# Altium schematic sheet IND_ENC_v2r0.SchDoc
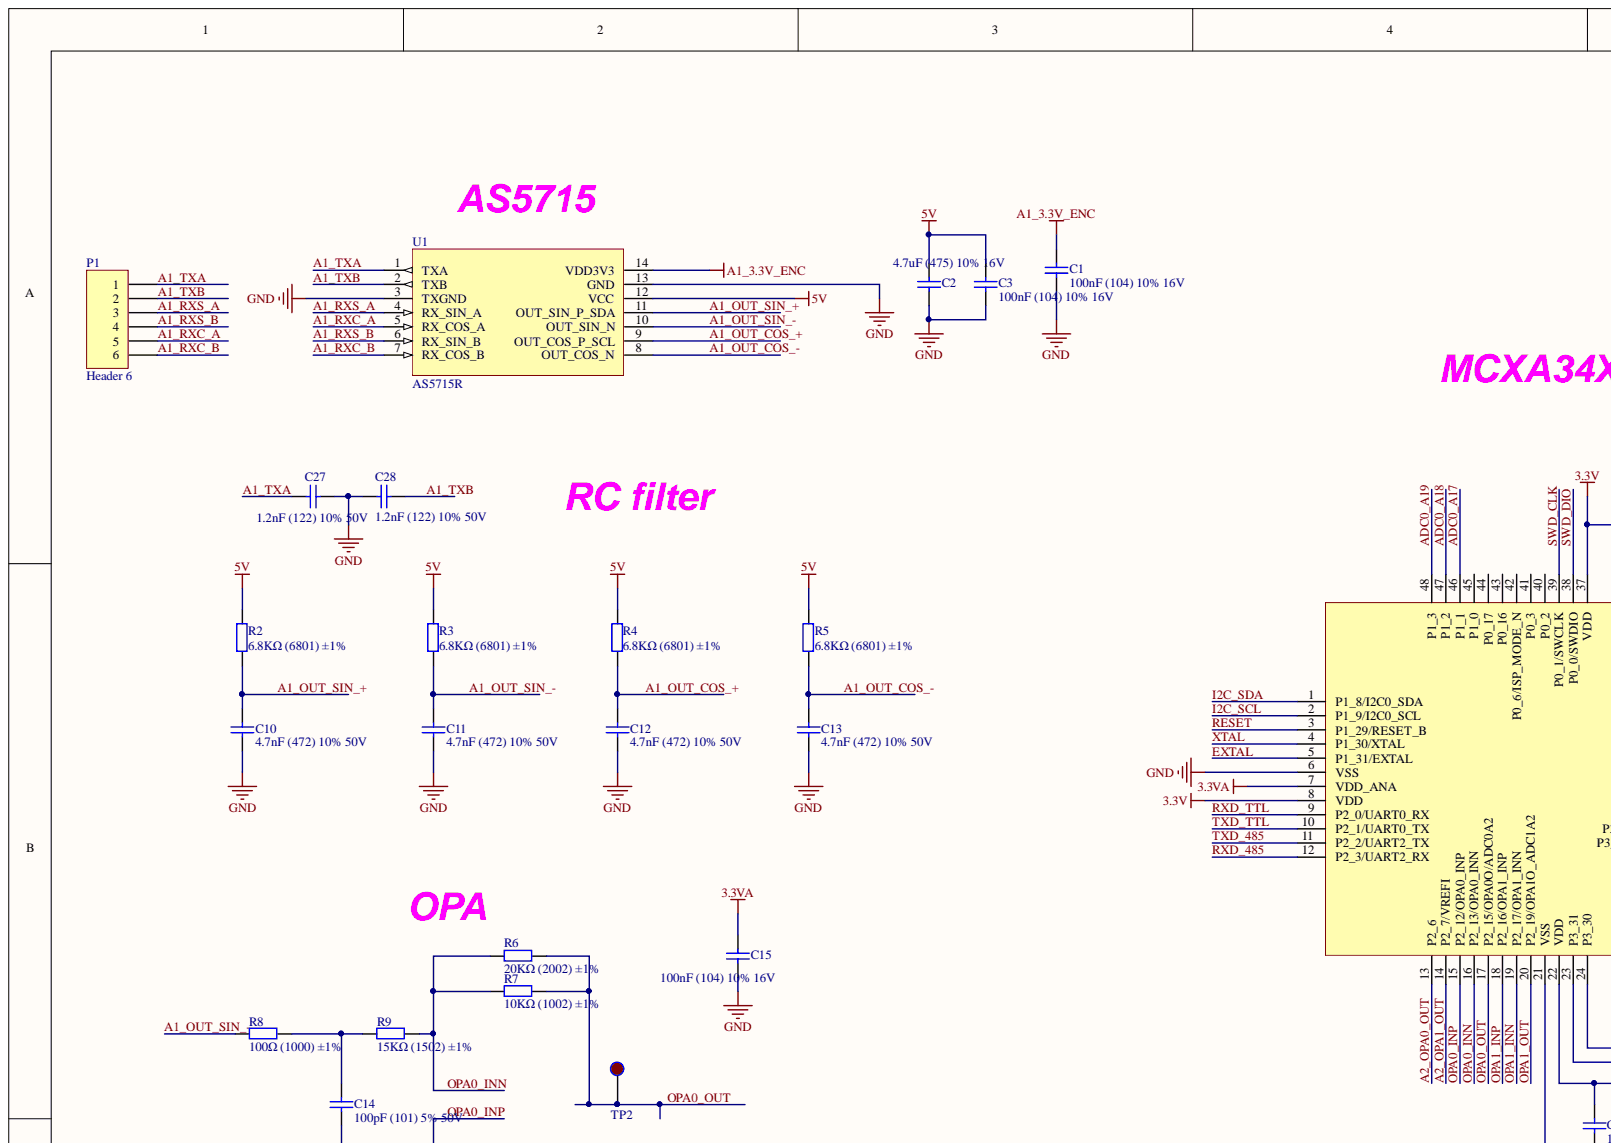
[diagram p0: IND_ENC_v2r0.SchDoc, top left]
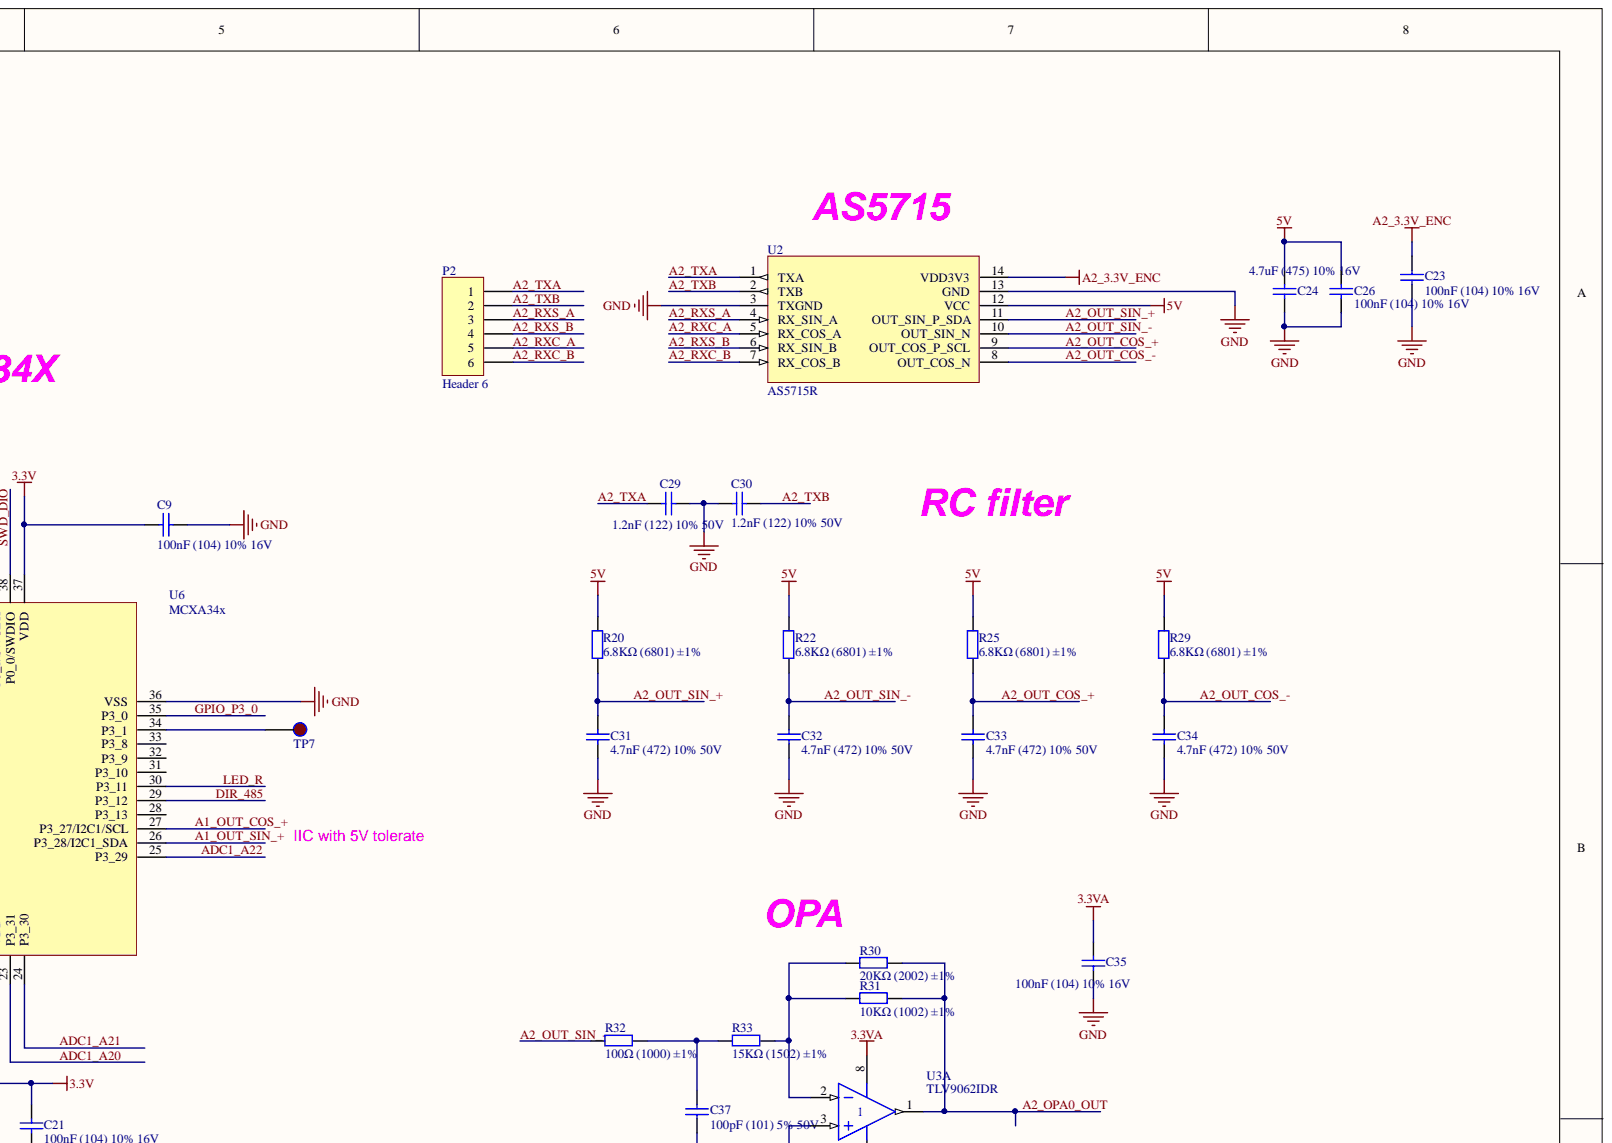
[diagram p1: IND_ENC_v2r0.SchDoc, top right]
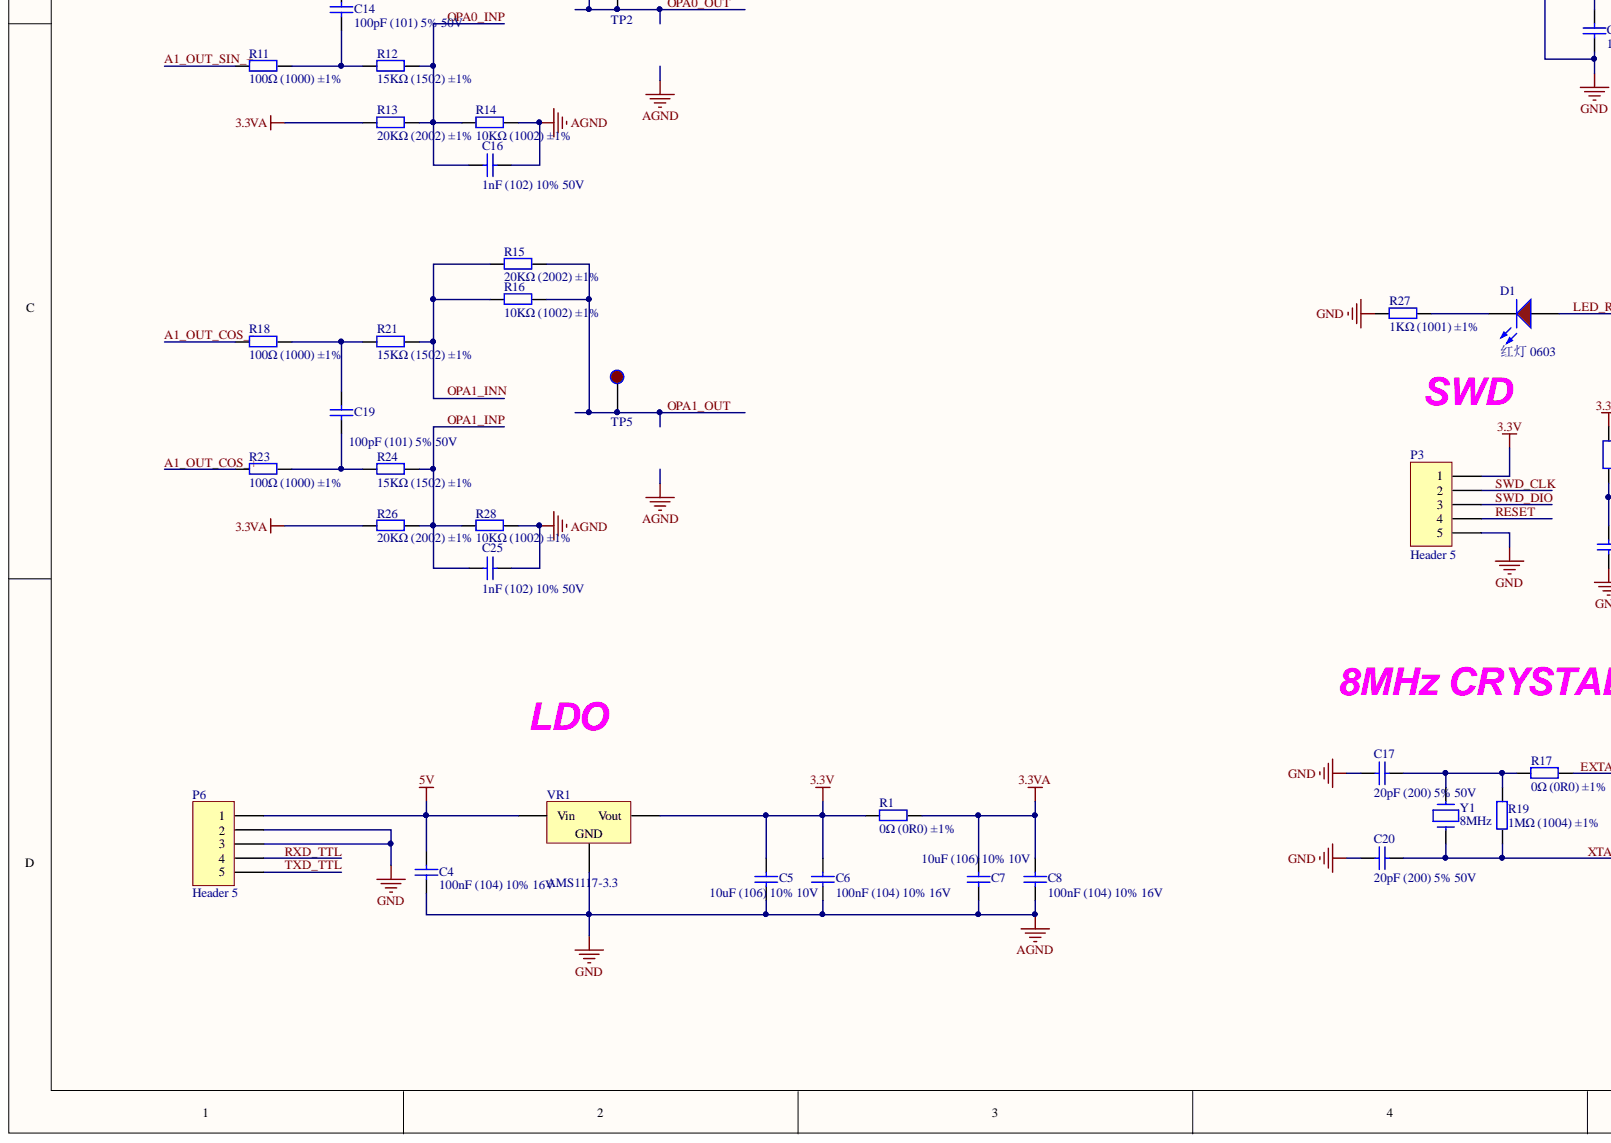
[diagram p2: IND_ENC_v2r0.SchDoc, bottom left]
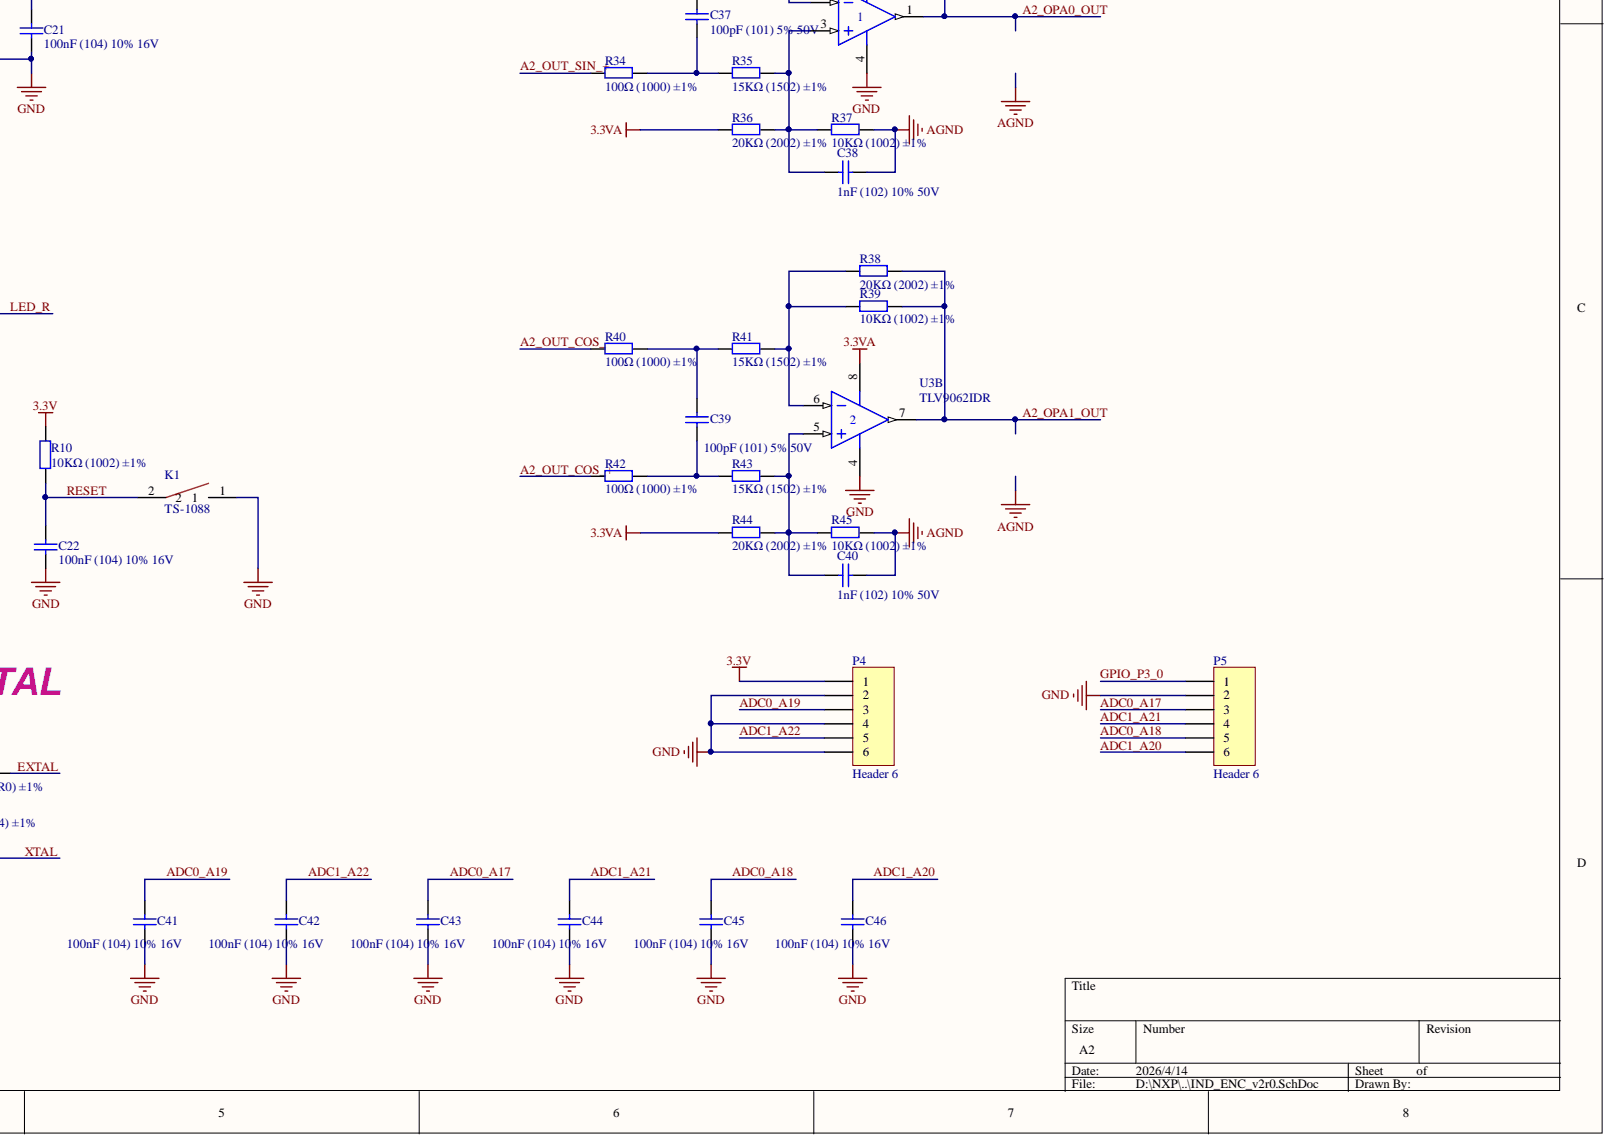
[diagram p3: IND_ENC_v2r0.SchDoc, bottom right]

## Parts
C1  100nF (104) 10% 16V  [0402_C]
C2  4.7uF (475) 10% 16V  [0603_C]
C3  100nF (104) 10% 16V  [0402_C]
C4  100nF (104) 10% 16V  [0402_C]
C5  10uF (106) 10% 10V  [0603_C]
C6  100nF (104) 10% 16V  [0402_C]
C7  10uF (106) 10% 10V  [0603_C]
C8  100nF (104) 10% 16V  [0402_C]
C9  100nF (104) 10% 16V  [0402_C]
C10  4.7nF (472) 10% 50V  [0402_C]
C11  4.7nF (472) 10% 50V  [0402_C]
C12  4.7nF (472) 10% 50V  [0402_C]
C13  4.7nF (472) 10% 50V  [0402_C]
C14  100pF (101) 5% 50V  [0402_C]
C15  100nF (104) 10% 16V  [0402_C]
C16  1nF (102) 10% 50V  [0402_C]
C17  20pF (200) 5% 50V  [0603_C]
C19  100pF (101) 5% 50V  [0402_C]
C20  20pF (200) 5% 50V  [0603_C]
C21  100nF (104) 10% 16V  [0402_C]
C22  100nF (104) 10% 16V  [0402_C]
C23  100nF (104) 10% 16V  [0402_C]
C24  4.7uF (475) 10% 16V  [0603_C]
C25  1nF (102) 10% 50V  [0402_C]
C26  100nF (104) 10% 16V  [0402_C]
C27  1.2nF (122) 10% 50V  [0603_C]
C28  1.2nF (122) 10% 50V  [0603_C]
C29  1.2nF (122) 10% 50V  [0603_C]
C30  1.2nF (122) 10% 50V  [0603_C]
C31  4.7nF (472) 10% 50V  [0402_C]
C32  4.7nF (472) 10% 50V  [0402_C]
C33  4.7nF (472) 10% 50V  [0402_C]
C34  4.7nF (472) 10% 50V  [0402_C]
C35  100nF (104) 10% 16V  [0402_C]
C37  100pF (101) 5% 50V  [0402_C]
C38  1nF (102) 10% 50V  [0402_C]
C39  100pF (101) 5% 50V  [0402_C]
C40  1nF (102) 10% 50V  [0402_C]
C41  100nF (104) 10% 16V  [0402_C]
C42  100nF (104) 10% 16V  [0402_C]
C43  100nF (104) 10% 16V  [0402_C]
C44  100nF (104) 10% 16V  [0402_C]
C45  100nF (104) 10% 16V  [0402_C]
C46  100nF (104) 10% 16V  [0402_C]
D1  红灯 0603  Package: 0603  [0603_LED_S1]
K1  TS-1088  [SW-SMD_L3.9-W3.0-P4.45]
P1  Header 6  [HDR1X6]
P2  Header 6  [HDR1X6]
P3  Header 5  [HDR1X5]
P4  Header 6  [HDR1X6]
P5  Header 6  [HDR1X6]
P6  Header 5  [HDR1X5]
R1  0Ω (0R0) ±1%  [0603_R]
R2  6.8KΩ (6801) ±1%  [0402_R]
R3  6.8KΩ (6801) ±1%  [0402_R]
R4  6.8KΩ (6801) ±1%  [0402_R]
R5  6.8KΩ (6801) ±1%  [0402_R]
R6  20KΩ (2002) ±1%  [0402_R]
R7  10KΩ (1002) ±1%  [0402_R]
R8  100Ω (1000) ±1%  [0402_R]
R9  15KΩ (1502) ±1%  [0402_R]
R10  10KΩ (1002) ±1%  [0402_R]
R11  100Ω (1000) ±1%  [0402_R]
R12  15KΩ (1502) ±1%  [0402_R]
R13  20KΩ (2002) ±1%  [0402_R]
R14  10KΩ (1002) ±1%  [0402_R]
R15  20KΩ (2002) ±1%  [0402_R]
R16  10KΩ (1002) ±1%  [0402_R]
R17  0Ω (0R0) ±1%  [0603_R]
R18  100Ω (1000) ±1%  [0402_R]
R19  1MΩ (1004) ±1%  [0603_R]
R20  6.8KΩ (6801) ±1%  [0402_R]
R21  15KΩ (1502) ±1%  [0402_R]
R22  6.8KΩ (6801) ±1%  [0402_R]
R23  100Ω (1000) ±1%  [0402_R]
R24  15KΩ (1502) ±1%  [0402_R]
R25  6.8KΩ (6801) ±1%  [0402_R]
R26  20KΩ (2002) ±1%  [0402_R]
R27  1KΩ (1001) ±1%  [0603_R]
R28  10KΩ (1002) ±1%  [0402_R]
R29  6.8KΩ (6801) ±1%  [0402_R]
R30  20KΩ (2002) ±1%  [0402_R]
R31  10KΩ (1002) ±1%  [0402_R]
R32  100Ω (1000) ±1%  [0402_R]
R33  15KΩ (1502) ±1%  [0402_R]
R34  100Ω (1000) ±1%  [0402_R]
R35  15KΩ (1502) ±1%  [0402_R]
R36  20KΩ (2002) ±1%  [0402_R]
R37  10KΩ (1002) ±1%  [0402_R]
R38  20KΩ (2002) ±1%  [0402_R]
R39  10KΩ (1002) ±1%  [0402_R]
R40  100Ω (1000) ±1%  [0402_R]
R41  15KΩ (1502) ±1%  [0402_R]
R42  100Ω (1000) ±1%  [0402_R]
R43  15KΩ (1502) ±1%  [0402_R]
R44  20KΩ (2002) ±1%  [0402_R]
R45  10KΩ (1002) ±1%  [0402_R]
TP2  TP?  [TPP]
TP5  TP?  [TPP]
TP7  TP?  [TP]
U1  AS5715R  [TSSOP-14]
U2  AS5715R  [TSSOP-14]
U3  TLV9062IDR  [SOIC-8_150mil]  (parts A,B)
U6  MCXA34x  [LQFP48]
VR1  AMS1117-3.3  [SOT-223]
Y1  8MHz  [SMD_5032_2P]

## Nets
3.3V: C5.2 C6.2 C9.2 C21.2 P3.1 P4.1 R1.1 R10.2 U6.8(VDD) U6.22(VDD) U6.37(VDD) VR1.2
3.3VA: C7.2 C8.2 C15.2 C35.2 R1.2 R13.1 R26.1 R36.1 R44.1 U3.8 U6.7(VDD_ANA)
5V: C2.2 C3.2 C4.2 C24.2 C26.2 P6.1 R2.2 R3.2 R4.2 R5.2 R20.2 R22.2 R25.2 R29.2 U1.12(VCC) U2.12(VCC) VR1.3
A1_3.3V_ENC: C1.2 U1.14(VDD3V3)
A2_3.3V_ENC: C23.2 U2.14(VDD3V3)
AGND: C1.1 C2.1 C3.1 C4.1 C5.1 C6.1 C7.1 C8.1 C9.1 C10.1 C11.1 C12.1 C13.1 C15.1 C16.2 C17.2 C20.2 C21.1 C22.1 C23.1 C24.1 C25.2 C26.1 C27.2 C28.1 C29.2 C30.1 C31.1 C32.1 C33.1 C34.1 C35.1 C38.2 C40.2 C41.1 C42.1 C43.1 C44.1 C45.1 C46.1 K1.1 P3.5 P4.2 P4.4 P4.6 P5.2 P6.2 P6.3 R14.2 R27.1 R28.2 R37.2 R45.2 U1.3(TXGND) U1.13(GND) U2.3(TXGND) U2.13(GND) U3.4 U6.6(VSS) U6.21(VSS) U6.36(VSS) VR1.1
A1_OUT_COS_+: C12.2 R4.1 R23.1 U1.9(OUT_COS_P_SCL) U6.27(P3_27/I2C1/SCL)
A1_OUT_COS_-: C13.2 R5.1 R18.1 U1.8(OUT_COS_N)
A1_OUT_SIN_+: C10.2 R2.1 R11.1 U1.11(OUT_SIN_P_SDA) U6.26(P3_28/I2C1_SDA)
A1_OUT_SIN_-: C11.2 R3.1 R8.1 U1.10(OUT_SIN_N)
A1_RXC_A: P1.5 U1.5(RX_COS_A)
A1_RXC_B: P1.6 U1.7(RX_COS_B)
A1_RXS_A: P1.3 U1.4(RX_SIN_A)
A1_RXS_B: P1.4 U1.6(RX_SIN_B)
A1_TXA: C27.1 P1.1 U1.1(TXA)
A1_TXB: C28.2 P1.2 U1.2(TXB)
A2_OPA0_OUT: R30.2 R31.2 U3.1 U6.13(P2_6)
A2_OPA1_OUT: R38.2 R39.2 U3.7 U6.14(P2_7/VREFI)
A2_OUT_COS_+: C33.2 R25.1 R42.1 U2.9(OUT_COS_P_SCL)
A2_OUT_COS_-: C34.2 R29.1 R40.1 U2.8(OUT_COS_N)
A2_OUT_SIN_+: C31.2 R20.1 R34.1 U2.11(OUT_SIN_P_SDA)
A2_OUT_SIN_-: C32.2 R22.1 R32.1 U2.10(OUT_SIN_N)
A2_RXC_A: P2.5 U2.5(RX_COS_A)
A2_RXC_B: P2.6 U2.7(RX_COS_B)
A2_RXS_A: P2.3 U2.4(RX_SIN_A)
A2_RXS_B: P2.4 U2.6(RX_SIN_B)
A2_TXA: C29.1 P2.1 U2.1(TXA)
A2_TXB: C30.2 P2.2 U2.2(TXB)
ADC0_A17: C43.2 P5.3 U6.46(P1_1)
ADC0_A18: C45.2 P5.5 U6.47(P1_2)
ADC0_A19: C41.2 P4.3 U6.48(P1_3)
ADC1_A20: C46.2 P5.6 U6.23(P3_31)
ADC1_A21: C44.2 P5.4 U6.24(P3_30)
ADC1_A22: C42.2 P4.5 U6.25(P3_29)
DIR_485: U6.29(P3_12)
EXTAL: R17.1 U6.5(P1_31/EXTAL)
GPIO_P3_0: P5.1 U6.35(P3_0)
I2C_SCL: U6.2(P1_9/I2C0_SCL)
I2C_SDA: U6.1(P1_8/I2C0_SDA)
LED_R: D1.A U6.30(P3_11)
OPA0_INN: R6.1 R7.1 R9.2 U6.16(P2_13/OPA0_INN)
OPA0_INP: C16.1 R12.2 R13.2 R14.1 U6.15(P2_12/OPA0_INP)
OPA0_OUT: R6.2 R7.2 TP2.1 U6.17(P2_15/OPA0O/ADC0A2)
OPA1_INN: R15.1 R16.1 R21.2 U6.19(P2_17/OPA1_INN)
OPA1_INP: C25.1 R24.2 R26.2 R28.1 U6.18(P2_16/OPA1_INP)
OPA1_OUT: R15.2 R16.2 TP5.1 U6.20(P2_19/OPA1O_ADC1A2)
RESET: C22.2 K1.2 P3.4 R10.1 U6.3(P1_29/RESET_B)
RXD_485: U6.12(P2_3/UART2_RX)
RXD_TTL: P6.4 U6.9(P2_0/UART0_RX)
SWD_CLK: P3.2 U6.39(P0_1/SWCLK)
SWD_DIO: P3.3 U6.38(P0_0/SWDIO)
TXD_485: U6.11(P2_2/UART2_TX)
TXD_TTL: P6.5 U6.10(P2_1/UART0_TX)
XTAL: C20.1 R19.1 U6.4(P1_30/XTAL) Y1.1
NetC14_1: C14.1 R11.2 R12.1
NetC14_2: C14.2 R8.2 R9.1
NetC17_1: C17.1 R17.2 R19.2 Y1.2
NetC19_1: C19.1 R23.2 R24.1
NetC19_2: C19.2 R18.2 R21.1
NetC37_1: C37.1 R34.2 R35.1
NetC37_2: C37.2 R32.2 R33.1
NetC38_1: C38.1 R35.2 R36.2 R37.1 U3.3
NetC39_1: C39.1 R42.2 R43.1
NetC39_2: C39.2 R40.2 R41.1
NetC40_1: C40.1 R43.2 R44.2 R45.1 U3.5
NetD1_K: D1.K R27.2
NetR30_1: R30.1 R31.1 R33.2 U3.2
NetR38_1: R38.1 R39.1 R41.2 U3.6
NetTP7_1: TP7.1 U6.34(P3_1)

Not connected: U6 28(P3_13) 31(P3_10) 32(P3_9) 33(P3_8) 40(P0_2) 41(P0_3) 42(P0_6/ISP_MODE_N) 43(P0_16) 44(P0_17) 45(P1_0)

## Library data of the parts
C1 C3 C4 C6 C8 C9 C15 C21-C23 C26 C35 C41-C46 = 0402 100nF (104) 10% 16V: 贴片电容; Component Kind: Standard; ComponentLink1Description: 供应商链接; ComponentLink1URL: http://www.szlcsc.com/product/details_1877.html; Library Name: Cap.SchLib; Package: 0402; Supplier: LC; SuppliersPartNumber: C1525
C2 C24 = 0603 4.7uF (475) 10% 16V: 贴片电容; Component Kind: Standard; ComponentLink1Description: 供应商链接; ComponentLink1URL: http://www.szlcsc.com/product/details_20375.html; Library Name: Cap.SchLib; Package: 0603; Supplier: LC; SuppliersPartNumber: C19666
C5 C7 = 0603 10uF (106) 10% 10V: 贴片电容; Component Kind: Standard; ComponentLink1Description: 供应商链接; ComponentLink1URL: http://www.szlcsc.com/product/details_20411.html; Library Name: Cap.SchLib; Package: 0603; Supplier: LC; SuppliersPartNumber: C19702
C10-C13 C31-C34 = 0402 4.7nF (472) 10% 50V: 贴片电容; Component Kind: Standard; ComponentLink1Description: 供应商链接; ComponentLink1URL: http://www.szlcsc.com/product/details_1890.html; Library Name: Cap.SchLib; Package: 0402; Supplier: LC; SuppliersPartNumber: C1538
C14 C19 C37 C39 = 0402 100pF (101) 5% 50V: 贴片电容; Component Kind: Standard; ComponentLink1Description: 供应商链接; ComponentLink1URL: http://www.szlcsc.com/product/details_1898.html; Library Name: Cap.SchLib; Package: 0402; Supplier: LC; SuppliersPartNumber: C1546
C16 C25 C38 C40 = 0402 1nF (102) 10% 50V: 贴片电容; Component Kind: Standard; ComponentLink1Description: 供应商链接; ComponentLink1URL: http://www.szlcsc.com/product/details_1875.html; Library Name: Cap.SchLib; Package: 0402; Supplier: LC; SuppliersPartNumber: C1523
C17 C20 = 0603 20pF (200) 5% 50V: 贴片电容; Component Kind: Standard; ComponentLink1Description: 供应商链接; ComponentLink1URL: http://www.szlcsc.com/product/details_2000.html; Library Name: Cap.SchLib; Package: 0603; Supplier: LC; SuppliersPartNumber: C1648
C27-C30 = 0603 1.2nF (122) 10% 50V: 贴片电容; Component Kind: Standard; ComponentLink1Description: 供应商链接; ComponentLink1URL: http://www.szlcsc.com/product/details_31372.html; Library Name: Cap.SchLib; Package: 0603; Supplier: LC; SuppliersPartNumber: C30615
D1 = 0603 红灯: 发光二极管; Component Kind: Standard; ComponentLink1Description: 供应商链接; ComponentLink1URL: http://www.szlcsc.com/product/details_2659.html; Library Name: LED.SchLib; Supplier: LC; SuppliersPartNumber: C2286
K1 = Key_2P: 贴片2脚按键; Component Kind: Standard; Library Name: MyLib.SCHLIB
P1 P2 P4 P5 = Header 6: Header, 6-Pin; Component Kind: Standard; LatestRevisionDate: 17-Jul-2002; LatestRevisionNote: Re-released for DXP Platform.; Library Name: Miscellaneous Connectors.IntLib; Signal Integrity: Connector; Publisher: Altium Limited
P3 P6 = Header 5: Header, 5-Pin; Component Kind: Standard; LatestRevisionDate: 17-Jul-2002; LatestRevisionNote: Re-released for DXP Platform.; Library Name: Miscellaneous Connectors.IntLib; Signal Integrity: Connector; Publisher: Altium Limited
R1 R17 = 0603 0Ω (0R0) 1%: 贴片电阻; Component Kind: Standard; ComponentLink1Description: 供应商链接; ComponentLink1URL: http://www.szlcsc.com/product/details_21903.html; Library Name: RES.SchLib; Package: 0603; Supplier: LC; SuppliersPartNumber: C21189
R2-R5 R20 R22 R25 R29 = 0402 6.8KΩ (6801) 1%: 贴片电阻; Component Kind: Standard; ComponentLink1Description: 供应商链接; ComponentLink1URL: http://www.szlcsc.com/product/details_26660.html; Library Name: RES.SchLib; Package: 0402; Supplier: LC; SuppliersPartNumber: C25917
R6 R13 R15 R26 R30 R36 R38 R44 = 0402 20KΩ (2002) 1%: 贴片电阻; Component Kind: Standard; ComponentLink1Description: 供应商链接; ComponentLink1URL: http://www.szlcsc.com/product/details_26508.html; Library Name: RES.SchLib; Package: 0402; Supplier: LC; SuppliersPartNumber: C25765
R7 R10 R14 R16 R28 R31 R37 R39 R45 = 0402 10KΩ (1002) 1%: 贴片电阻; Component Kind: Standard; ComponentLink1Description: 供应商链接; ComponentLink1URL: http://www.szlcsc.com/product/details_26487.html; Library Name: RES.SchLib; Package: 0402; Supplier: LC; SuppliersPartNumber: C25744
R8 R11 R18 R23 R32 R34 R40 R42 = 0402 100Ω (1000) 1%: 贴片电阻; Component Kind: Standard; ComponentLink1Description: 供应商链接; ComponentLink1URL: http://www.szlcsc.com/product/details_25819.html; Library Name: RES.SchLib; Package: 0402; Supplier: LC; SuppliersPartNumber: C25076
R9 R12 R21 R24 R33 R35 R41 R43 = 0402 15KΩ (1502) 1%: 贴片电阻; Component Kind: Standard; ComponentLink1Description: 供应商链接; ComponentLink1URL: http://www.szlcsc.com/product/details_26499.html; Library Name: RES.SchLib; Package: 0402; Supplier: LC; SuppliersPartNumber: C25756
R19 = 0603 1MΩ (1004) 1%: 贴片电阻; Component Kind: Standard; ComponentLink1Description: 供应商链接; ComponentLink1URL: http://www.szlcsc.com/product/details_23662.html; Library Name: RES.SchLib; Package: 0603; Supplier: LC; SuppliersPartNumber: C22935
R27 = 0603 1KΩ (1001) 1%: 贴片电阻; Component Kind: Standard; ComponentLink1Description: 供应商链接; ComponentLink1URL: http://www.szlcsc.com/product/details_21904.html; Library Name: RES.SchLib; Package: 0603; Supplier: LC; SuppliersPartNumber: C21190
TP2 TP5 TP7 = Test Point: Component Kind: Standard; Library Name: MyLib.SCHLIB
U1 U2 = AS5715R: AMS; Component Kind: Standard; Library Name: MyLib.SCHLIB
U3 = LM358AJG: Dual Operational Amplifier; Component Kind: Standard; ComponentLink1Description: Manufacturer Link; ComponentLink1URL: http://www.ti.com/; DatasheetVersion: Aug-1988; LatestRevisionDate: 04-Mar-2005; LatestRevisionNote: Stylized 3D Model Added.; Library Name: TI Operational Amplifier.IntLib; PackageDescription: DIP; 8 Leads; Row Spacing 7.62 mm; Pitch 2.54 mm; PackageReference: JG008; PackageVersion: Jan-1995; PCB3D: JG008; Published: 15-Apr-2002; Publisher: Altium Limited
U6 = MCXA34xVLF: LQFP48; Component Kind: Standard; Library Name: MyLib.SCHLIB
VR1 = SOT-223 AMS1117-3.3: 低压差线性稳压(LDO); Component Kind: Standard; ComponentLink1Description: 供应商链接; ComponentLink1URL: http://www.szlcsc.com/product/details_6652.html; Library Name: LDO.SchLib; Package: SOT-223; Supplier: LC; SuppliersPartNumber: C6186
Y1 = Crystal: 晶振; Component Kind: Standard; Library Name: MyLib.SCHLIB
